# Altium schematic sheet schematic.SchDoc
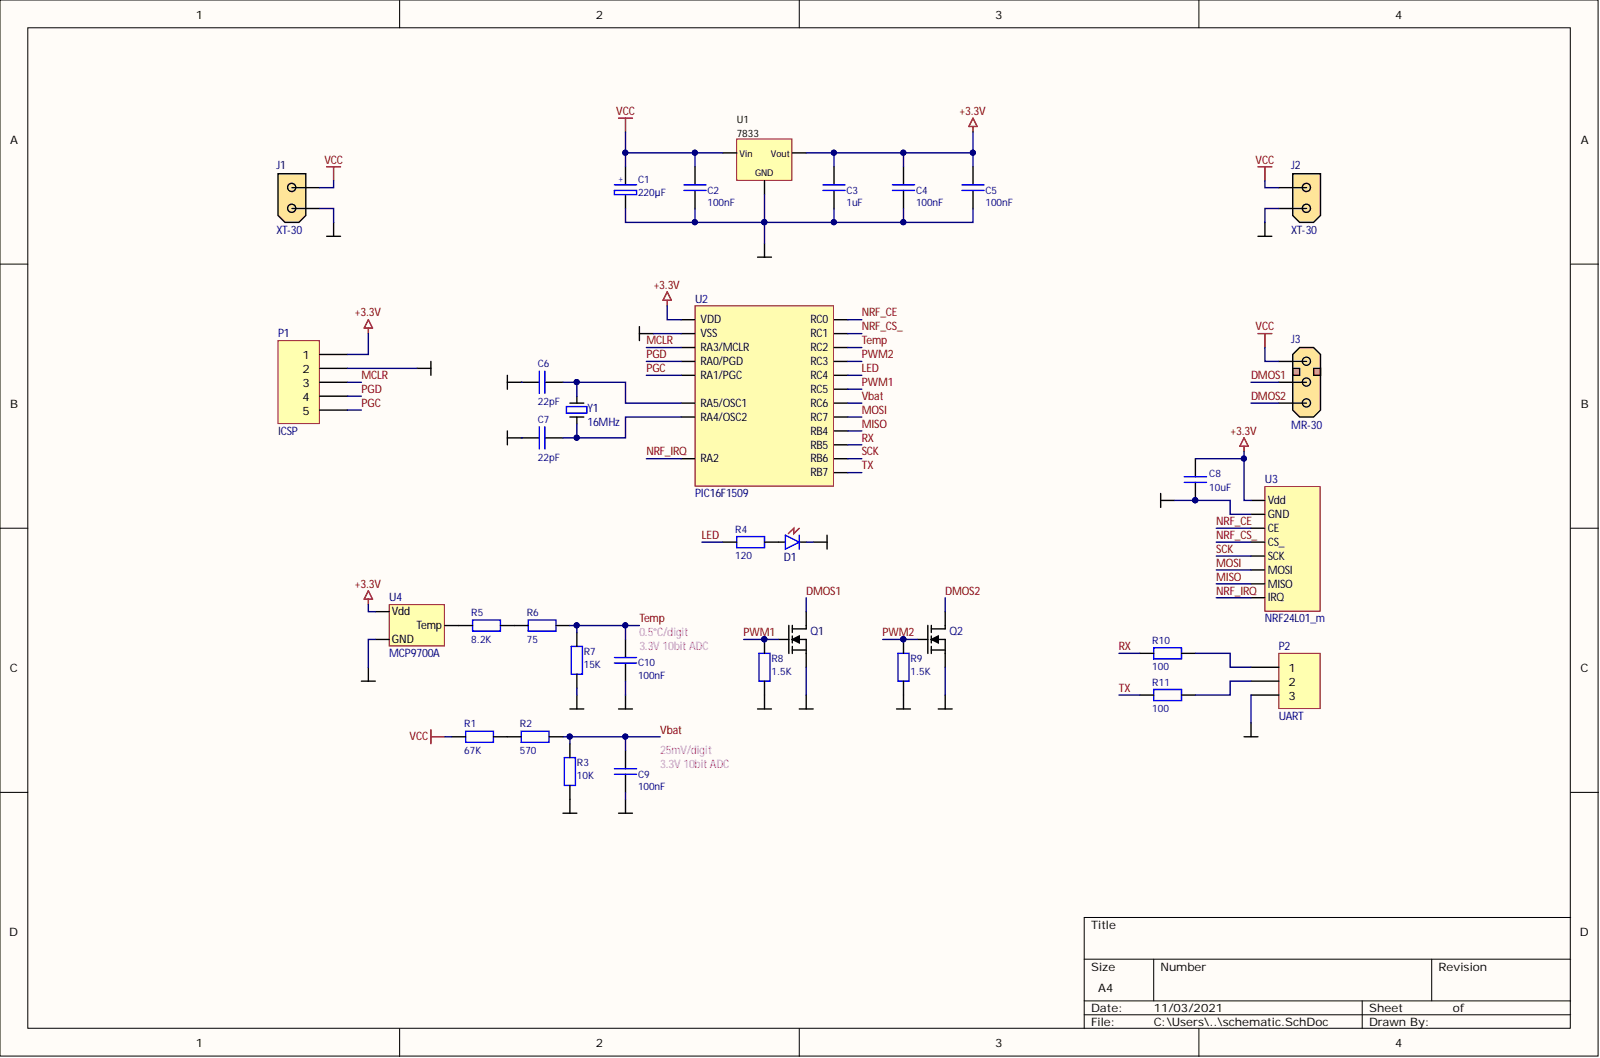
[diagram p0: schematic.SchDoc]

## Parts
C1  C  value 220µF  [CAP-E-8x12-3.5mm]
C2  C  value 100nF  [CAP-0603]
C3  C  value 1uF  [CAP-0805]
C4  C  value 100nF  [CAP-0603]
C5  C  value 100nF  [CAP-0603]
C6  C  value 22pF  [CAP-0603]
C7  C  value 22pF  [CAP-0603]
C8  C  value 10uF  [CAP-1206]
C9  C  value 100nF  [CAP-0603]
C10  C  value 100nF  [CAP-0603]
D1  LED  [0603 LED R]  (value not drawn)
J1  Type: XT-30  [XT30PW-F]  (hidden comment: Amass)
J2  Type: XT-30  [XT30PW-M]  (hidden comment: Amass)
J3  Amass  [MR30PW-FB]  (value not drawn)
P1  ICSP  [HDR1X5]
P2  UART  [Header1X3]
Q1  N-MOS  [SOT-23]  (value not drawn)
Q2  N-MOS  [SOT-23]  (value not drawn)
R1  R  value 67K  [RES-0603]
R2  R  value 570  [RES-0603]
R3  R  value 10K  [RES-0603]
R4  R  value 120  [RES-0603]
R5  R  value 8.2K  [RES-0603]
R6  R  value 75  [RES-0603]
R7  R  value 15K  [RES-0603]
R8  R  value 1.5K  [RES-0603]
R9  R  value 1.5K  [RES-0603]
R10  R  value 100  [RES-0603]
R11  R  value 100  [RES-0603]
U1  7833  [SOT-223 (4pins)]
U2  PIC16F1509  [SSOP20]  (value not drawn)
U3  NRF24L01_m  [NRF24L01_SMD]
U4  MCP9700A  [SOT-23]
Y1  Frquency: 16MHz  [ABM8]  (hidden comment: Crystal)

## Nets
+3.3V: C3.2 C4.2 C5.2 C8.2 P1.1 U1.3 U2.1(VDD) U3.1(Vdd) U4.1
GND: C1.2 C2.1 C3.1 C4.1 C5.1 C6.2 C7.2 C8.1 C9.1 C10.1 D1.2 J1.2 J2.2 P1.2 P2.3 Q1.2 Q2.2 R3.2 R7.2 R8.2 R9.2 U1.2 U2.20(VSS) U3.2(GND) U4.3
VCC: C1.1 C2.2 J1.1 J2.1 J3.1 R1.1 U1.1
DMOS1: J3.2 Q1.3
DMOS2: J3.3 Q2.3
LED: R4.1 U2.6(RC4)
MCLR: P1.3 U2.4(RA3/MCLR)
MISO: U2.13(RB4) U3.7(MISO)
MOSI: U2.9(RC7) U3.6(MOSI)
NRF_CE: U2.16(RC0) U3.3(CE)
NRF_CS_: U2.15(RC1) U3.4(CS_)
NRF_IRQ: U2.17(RA2) U3.8(IRQ)
PGC: P1.5 U2.18(RA1/PGC)
PGD: P1.4 U2.19(RA0/PGD)
PWM1: Q1.1 R8.1 U2.5(RC5)
PWM2: Q2.1 R9.1 U2.7(RC3)
RX: R10.1 U2.12(RB5)
SCK: U2.11(RB6) U3.5(SCK)
Temp: C10.2 R6.2 R7.1 U2.14(RC2)
TX: R11.1 U2.10(RB7)
Vbat: C9.2 R2.2 R3.1 U2.8(RC6)
NetC6_1: C6.1 U2.2(RA5/OSC1) Y1.2
NetC7_1: C7.1 U2.3(RA4/OSC2) Y1.1
NetD1_1: D1.1 R4.2
NetP2_1: P2.1 R10.2
NetP2_2: P2.2 R11.2
NetR1_2: R1.2 R2.1
NetR5_1: R5.1 U4.2
NetR5_2: R5.2 R6.1

## Library data of the parts
C1 = C-Elec: electrolytic capacitor; Tolerence: 10%; Type: XR7; Voltage: 50; Component Kind: Standard; Library Name: LIB_PERSO.IntLib
C2-C7 C9 C10 = C: capacitor; Tolerence: 10%; Type: XR7; Voltage: 50; Component Kind: Standard; Library Name: LibPerso_1.SchLib
C8 = C: capacitor; Manufacturer: Murata; Reference: GRM319R6YA106KA12D; Tolerence: 10%; Type: X5R; Voltage: 35; Component Kind: Standard; Library Name: LibPerso_1.SchLib
D1 = LED: Color: red; Forward current: 20mA; Forward voltage: 2.2; Component Kind: Standard; Description: LED; Library Name: LIB_PERSO.IntLib
J1 J2 = XT-Series: AmassXT connector series; Component Kind: Standard; Manufacturer: Amass; Library Name: LIB_PERSO.IntLib
J3 = MR-30: Amass MR30 Series; Component Kind: Standard; Manufacturer: Amass; Type: MR-30; Library Name: LIB_PERSO.IntLib
P1 = Header 5: Header, 5-Pin; Manufacturer: No; Reference: 5PH; Component Kind: Standard; Library Name: Miscellaneous Connectors.IntLib; Signal Integrity: Connector
P2 = Header 3: Header, 3-Pin; Manufacturer: No; Reference: 3PH; Component Kind: Standard; Library Name: Miscellaneous Connectors.IntLib; Signal Integrity: Connector
Q1 Q2 = N-MOSFET: N channel MOSFET; Manufacturer: NEXPERIA; Power: 1W; Reference: PMV40UN2R; VDS_Max: 30V; VGS_th: 650mV; Component Kind: Standard; Library Name: LIB_PERSO.IntLib
R1-R11 = R: Resistor; Power: 250mW; Tolerence: 1%; Voltage: 150V; Component Kind: Standard; Library Name: LIB_PERSO.IntLib
U1 = 78xx: Linear positive voltage regulator 78xx series; Manufacturer: Texas Instrument; Reference: UA78M33QDCYRG4Q1; Voltage Regulation: 3.3V; Component Kind: Standard; Library Name: LIB_PERSO.IntLib
U2 = PIC16F1509: Microchip 8bit MCU; Reference: PIC16F1509T-I/SS; Component Kind: Standard; Library Name: MCU.SchLib; Manufacturer: Microchip
U3 = NRF24L01_module: Manufacturer: No; Reference: NRF24L01P; Component Kind: Standard; Library Name: LIB_PERSO.IntLib
U4 = MCP9700: Analog +/2°C temperature sensor; Manufacturer: Microchip; Reference: MCP9700AT-E/TT; Component Kind: Standard; Library Name: LIB_PERSO.IntLib
Y1 = XTAL: Manufacturer: Abracon; Reference: ABM8-16.000MHZ-9-B1U-T; Component Kind: Standard; Library Name: LibPerso_1.SchLib
